# Altium schematic sheet Current Sensor Interface.SchDoc("Current Sensor Interface")
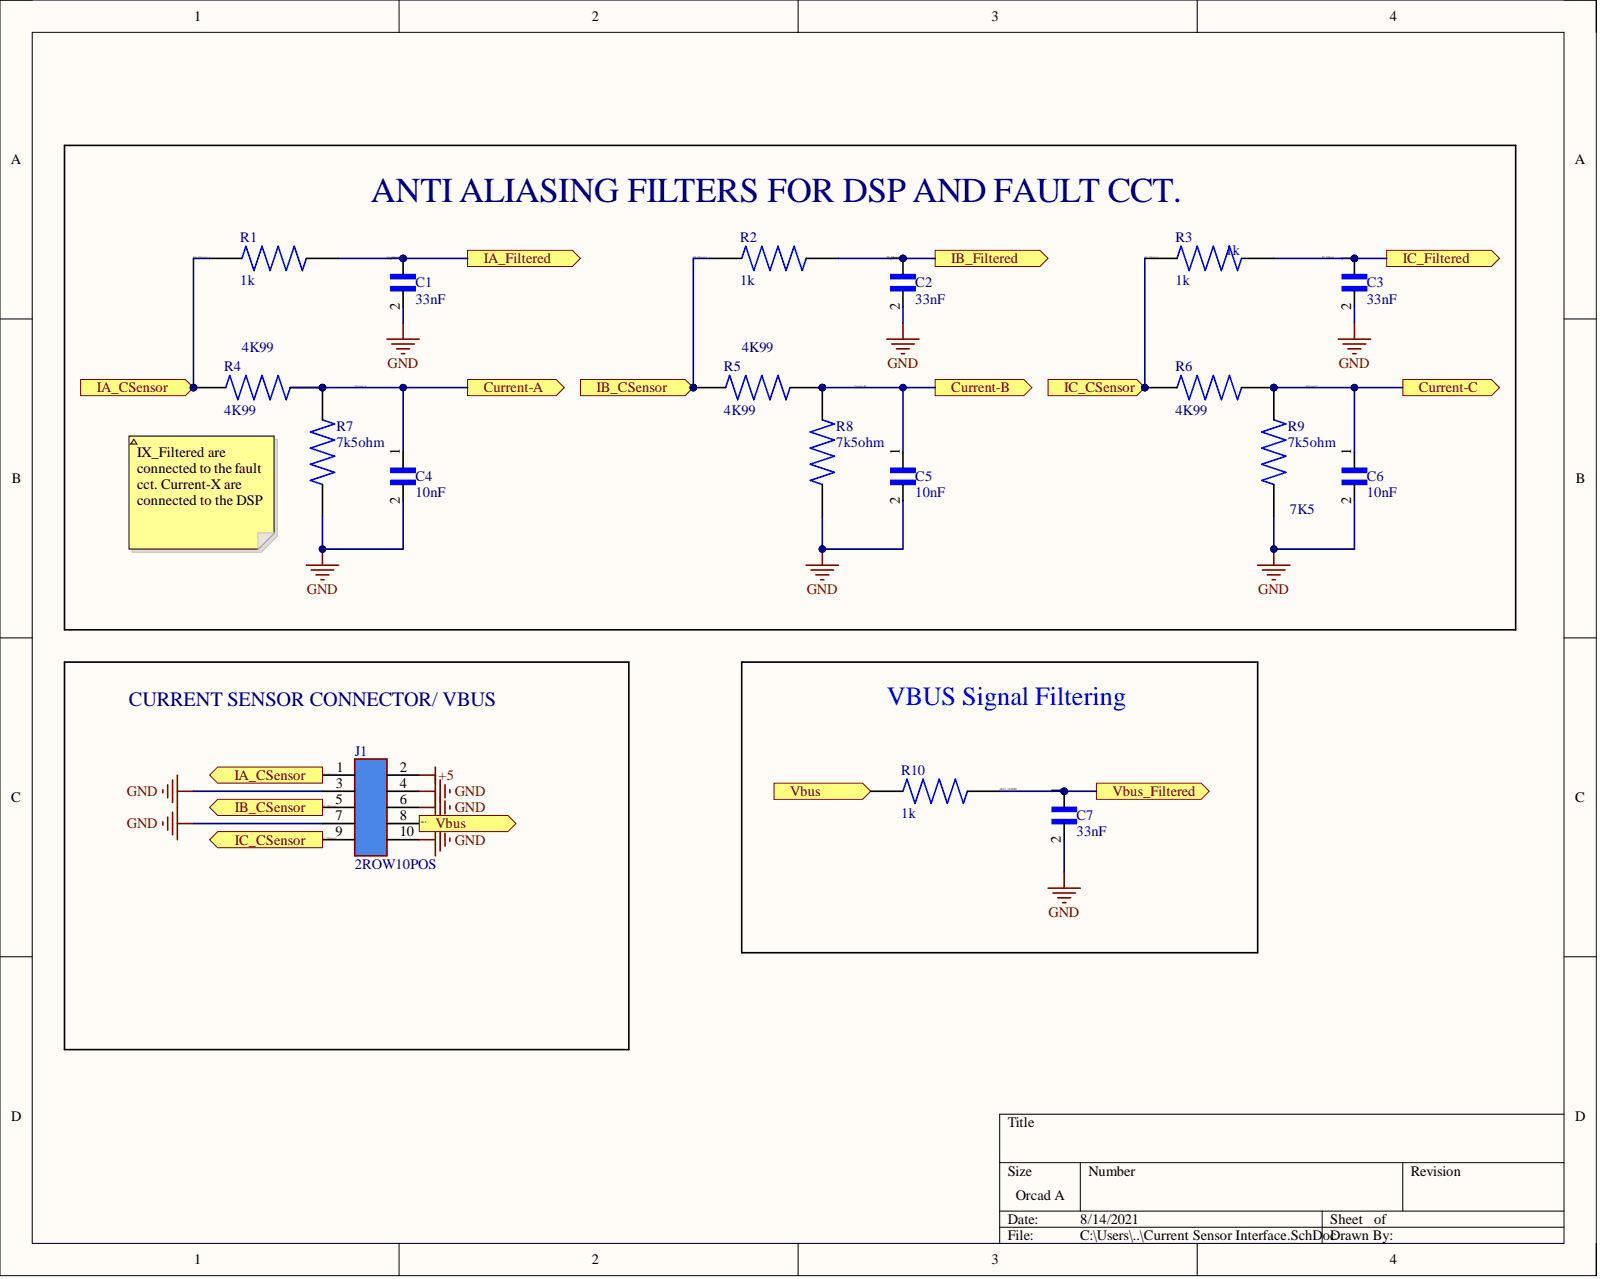
[diagram p0: Current Sensor Interface.SchDoc]

## Parts
C1  33nF  [CAP 0805(2012 metric)]
C2  33nF  [CAP 0805(2012 metric)]
C3  33nF  [CAP 0805(2012 metric)]
C4  10nF  [CAP 0805(2012 metric)]
C5  10nF  [CAP 0805(2012 metric)]
C6  10nF  [CAP 0805(2012 metric)]
C7  33nF  [CAP 0805(2012 metric)]
J1  2ROW10POS  [2ROW10POS]
R1  1k  [RES 0805(2012 metric)]
R2  1k  [RES 0805(2012 metric)]
R3  1k  [RES 0805(2012 metric)]
R4  4K99  [RES 0805(2012 metric)]
R5  4K99  [RES 0805(2012 metric)]
R6  4K99  [RES 0805(2012 metric)]
R7  7k5ohm  [RES 0805(2012 metric)]
R8  7k5ohm  [RES 0805(2012 metric)]
R9  7k5ohm  [RES 0805(2012 metric)]
R10  1k  [RES 0805(2012 metric)]

## Ports
Current-A, Current-B, Current-C, IA_CSensor, IA_Filtered, IB_CSensor, IB_Filtered, IC_CSensor, IC_Filtered, Vbus, Vbus_Filtered

## Nets
+5: J1.2
GND: C1.2 C2.2 C3.2 C4.2 C5.2 C6.2 C7.2 J1.3 J1.4 J1.6 J1.7 J1.10 R7.1 R8.1 R9.1
Current-A: C4.1 R4.2 R7.2 ; port Current-A
Current-B: C5.1 R5.2 R8.2 ; port Current-B
Current-C: C6.1 R6.2 R9.2 ; port Current-C
IA_CSensor: J1.1 R1.1 R4.1 ; port IA_CSensor
IA_Filtered: C1.1 R1.2 ; port IA_Filtered
IB_CSensor: J1.5 R2.1 R5.1 ; port IB_CSensor
IB_Filtered: C2.1 R2.2 ; port IB_Filtered
IC_CSensor: J1.9 R3.1 R6.1 ; port IC_CSensor
IC_Filtered: C3.1 R3.2 ; port IC_Filtered
VBUS: J1.8 R10.1 ; port Vbus
VBUS_FILTERED: C7.1 R10.2 ; port Vbus_Filtered

## Library data of the parts
C1-C3 C7 = 33nF: 0.033µF ±10% 100V Ceramic Capacitor X7R 0805 (2012 Metric); Component Kind: Standard; Library Name: 33nF 0805.SchLib
C4-C6 = 10nF: 10000pF ±10% 25V Ceramic Capacitor X7R 0805 (2012 Metric); Component Kind: Standard; Library Name: 10nF 0805 25V.SchLib
R1-R3 R10 = 1k: Resistor 0805 1k ohm 0.1W; Component Kind: Standard; Library Name: 1k 0805 0.1W.SchLib
J1 = 2ROW10POS: Component Kind: Standard; Library Name: 2ROW10POS.SchLib
R4-R6 = 4k99: Component Kind: Standard; Library Name: 4k99 0805.SchLib
R7-R9 = 7k5ohm: Component Kind: Standard; Description: 7k5ohm; Library Name: 7k5 0805.SchLib
